# Altium schematic sheet PAB.SchDoc(PAB)
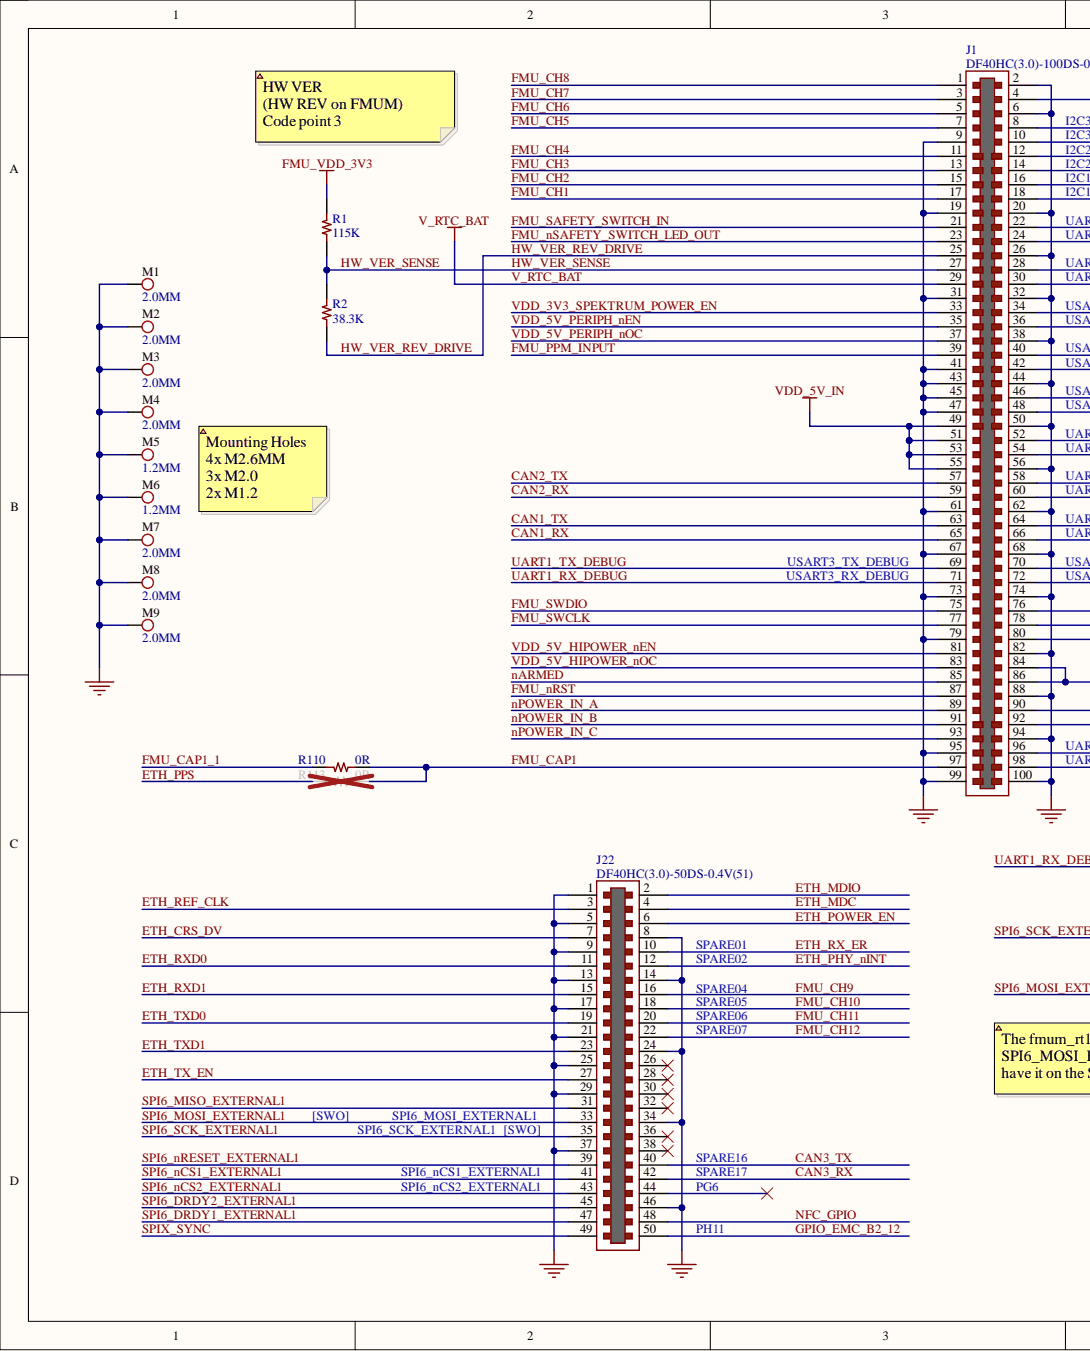
[diagram p0: PAB.SchDoc, left]
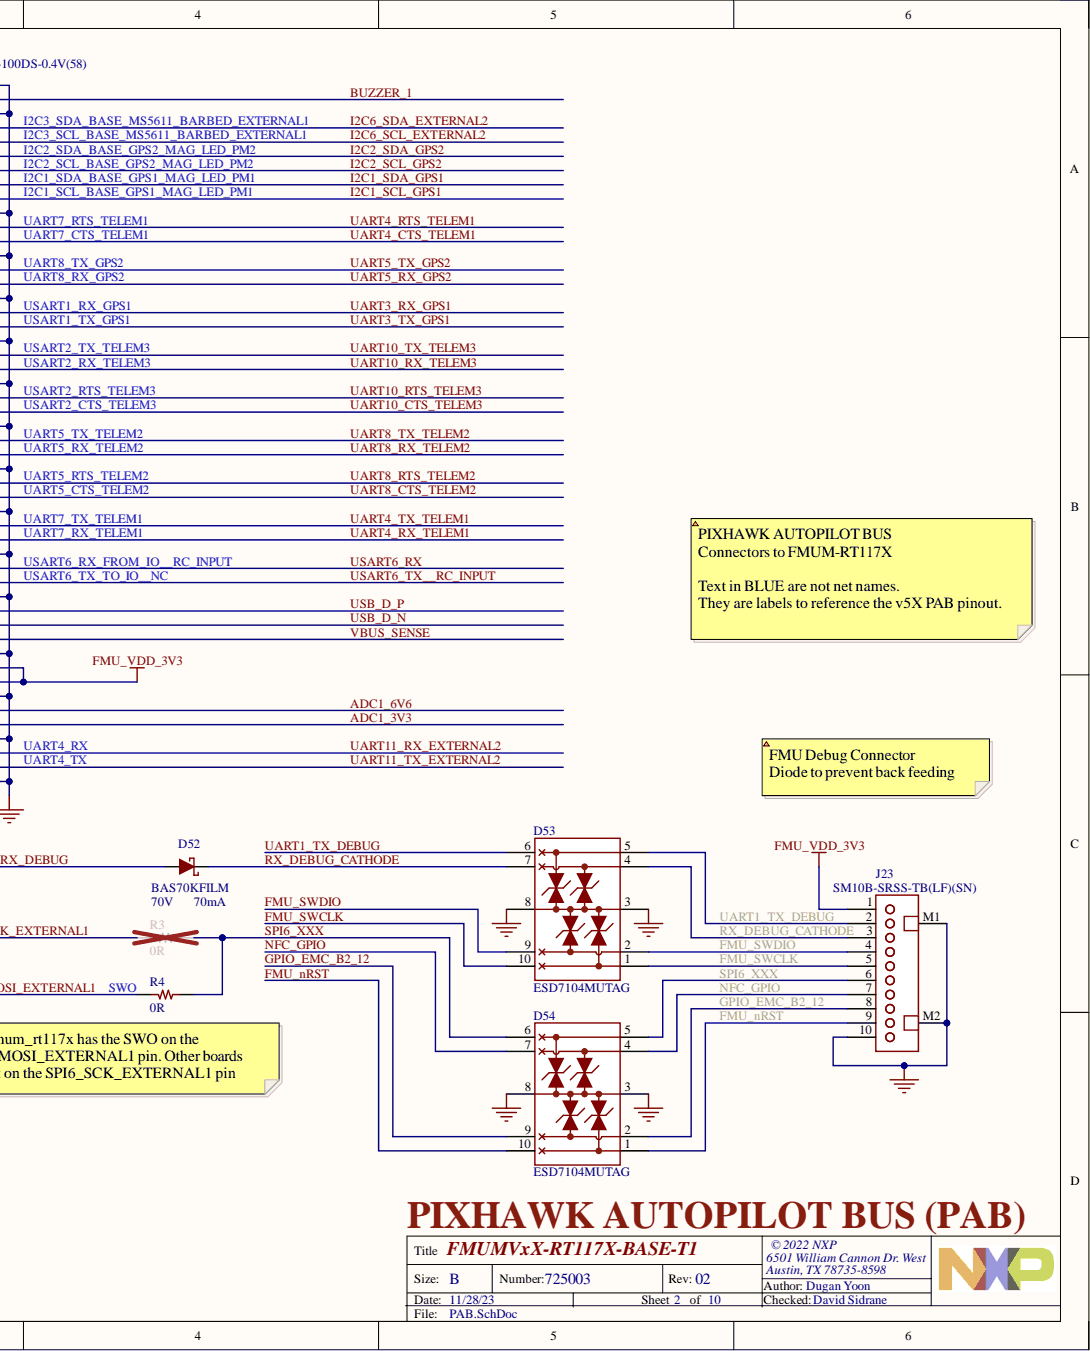
[diagram p1: PAB.SchDoc, right]

## Parts
D52  Current: 70mA  Manufacturer Part Number: BAS70KFILM  [SODFL120X80X70L30X30]  (hidden comment: DIODE SCHOTTKY 70V 70MA SOD523)
D53  Manufacturer Part Number: ESD7104MUTAG  [UDFN10P50_250X100X55L35X20N]  (hidden comment: DIODE TVS BIDIRECTIONAL 5V 10V 10UDFN)
D54  Manufacturer Part Number: ESD7104MUTAG  [UDFN10P50_250X100X55L35X20N]  (hidden comment: DIODE TVS BIDIRECTIONAL 5V 10V 10UDFN)
J1  Manufacturer Part Number: DF40HC(3.0)-100DS-0.4V(58)  [HIROSE_DF40HC3_0-100DS-0_4V51]  (hidden comment: CONN RCPT 100POS 0.4MM SMD GOLD)
J22  Manufacturer Part Number: DF40HC(3.0)-50DS-0.4V(51)  [HIROSE_DF40C-50DS-0-4V51]  (hidden comment: CONN RCPT 50POS 0.4MM SMD GOLD)
J23  Manufacturer Part Number: SM10B-SRSS-TB(LF)(SN)  [JST_SM10B-SRSS-TB]  (hidden comment: CONN HEADER SH 10POS SIDE 1MM SMT)
M1  ID: 2.0MM  [MOUNT_HOLE_2MM_3.6MM_8via_TH]  (hidden comment: MOUNT HOLE 2MM 3.6MM 8VIAS TH)  (no BOM)
M2  ID: 2.0MM  [MOUNT_HOLE_2MM_3.6MM_8via_TH]  (hidden comment: MOUNT HOLE 2MM 3.6MM 8VIAS TH)  (no BOM)
M3  ID: 2.0MM  [MOUNT_HOLE_2MM_3.6MM_8via_TH]  (hidden comment: MOUNT HOLE 2MM 3.6MM 8VIAS TH)  (no BOM)
M4  ID: 2.0MM  [MOUNT_HOLE_2MM_3.6MM_8via_TH]  (hidden comment: MOUNT HOLE 2MM 3.6MM 8VIAS TH)  (no BOM)
M5  ID: 1.2MM  [MOUNT_HOLE_1.2MM_TH]  (hidden comment: MOUNT HOLE 1.2MM TH)  (no BOM)
M6  ID: 1.2MM  [MOUNT_HOLE_1.2MM_TH]  (hidden comment: MOUNT HOLE 1.2MM TH)  (no BOM)
M7  ID: 2.0MM  [MOUNT_HOLE_2MM_3.6MM_8via_TH]  (hidden comment: MOUNT HOLE 2MM 3.6MM 8VIAS TH)  (no BOM)
M8  ID: 2.0MM  [MOUNT_HOLE_2MM_3.6MM_8via_TH]  (hidden comment: MOUNT HOLE 2MM 3.6MM 8VIAS TH)  (no BOM)
M9  ID: 2.0MM  [MOUNT_HOLE_2MM_3.6MM_8via_TH]  (hidden comment: MOUNT HOLE 2MM 3.6MM 8VIAS TH)  (no BOM)
R1  value 115K  [RESC100X50X40L25]  (hidden comment: RES SMD 115K OHM 1% 1/16W 0402)
R2  value 38.3K  [RESC100X50X40L25]  (hidden comment: RES SMD 38.3K OHM 1% 1/16W 0402)
R3  value 0R  [RESC100X50X40L25]  (hidden comment: RES SMD 0 OHM 1% 1/16W 0402)
R4  value 0R  [RESC100X50X40L25]  (hidden comment: RES SMD 0 OHM 1% 1/16W 0402)
R110  value 0R  [RESC100X50X40L25]  (hidden comment: RES SMD 0 OHM 1% 1/16W 0402)
R113  value 0R  [RESC100X50X40L25]  (hidden comment: RES SMD 0 OHM 1% 1/16W 0402)

## Nets
FMU_VDD_3V3: J1.84 J1.86 J23.1 R1.1
GND: D53.3 D53.8 D54.3 D54.8 J1.2 J1.6 J1.9 J1.19 J1.20 J1.26 J1.31 J1.32 J1.38 J1.41 J1.43 J1.44 J1.45 J1.47 J1.50 J1.56 J1.61 J1.62 J1.67 J1.68 J1.73 J1.74 J1.79 J1.82 J1.88 J1.94 J1.95 J1.99 J1.100 J22.1 J22.5 J22.8 J22.9 J22.13 J22.14 J22.17 J22.21 J22.24 J22.25 J22.29 J22.34 J22.37 J22.46 J23.10 J23.M1 J23.M2 M1.1 M2.1 M3.1 M4.1 M5.1 M6.1 M7.1 M8.1 M9.1
VDD_5V_IN: J1.49 J1.51 J1.53 J1.55
ADC1_3V3: J1.92
ADC1_6V6: J1.90
BUZZER_1: J1.4
CAN1_RX: J1.65
CAN1_TX: J1.63
CAN2_RX: J1.59
CAN2_TX: J1.57
CAN3_RX: J22.42
CAN3_TX: J22.40
ETH_CRS_DV: J22.7
ETH_MDC: J22.4
ETH_MDIO: J22.2
ETH_PHY_nINT: J22.12
ETH_POWER_EN: J22.6
ETH_PPS: R113.1
ETH_REF_CLK: J22.3
ETH_RX_ER: J22.10
ETH_RXD0: J22.11
ETH_RXD1: J22.15
ETH_TX_EN: J22.27
ETH_TXD0: J22.19
ETH_TXD1: J22.23
FMU_CAP1: J1.97 R110.2 R113.2
FMU_CAP1_1: R110.1
FMU_CH1: J1.17
FMU_CH2: J1.15
FMU_CH3: J1.13
FMU_CH4: J1.11
FMU_CH5: J1.7
FMU_CH6: J1.5
FMU_CH7: J1.3
FMU_CH8: J1.1
FMU_CH9: J22.16
FMU_CH10: J22.18
FMU_CH11: J22.20
FMU_CH12: J22.22
FMU_nRST: D54.1 D54.10 J1.87 J23.9
FMU_nSAFETY_SWITCH_LED_OUT: J1.23
FMU_PPM_INPUT: J1.39
FMU_SAFETY_SWITCH_IN: J1.21
FMU_SWCLK: D53.1 D53.10 J1.77 J23.5
FMU_SWDIO: D53.2 D53.9 J1.75 J23.4
GPIO_EMC_B2_12: D54.2 D54.9 J22.50 J23.8
HW_VER_REV_DRIVE: J1.25 R2.2
HW_VER_SENSE: J1.27 R1.2 R2.1
I2C1_SCL_GPS1: J1.18
I2C1_SDA_GPS1: J1.16
I2C2_SCL_GPS2: J1.14
I2C2_SDA_GPS2: J1.12
I2C6_SCL_EXTERNAL2: J1.10
I2C6_SDA_EXTERNAL2: J1.8
nARMED: J1.85
NFC_GPIO: D54.4 D54.7 J22.48 J23.7
nPOWER_IN_A: J1.89
nPOWER_IN_B: J1.91
nPOWER_IN_C: J1.93
RX_DEBUG_CATHODE: D52.C D53.4 D53.7 J23.3
SPI6_DRDY1_EXTERNAL1: J22.47
SPI6_DRDY2_EXTERNAL1: J22.45
SPI6_MISO_EXTERNAL1: J22.31
SPI6_MOSI_EXTERNAL1: J22.33 R4.1
SPI6_nCS1_EXTERNAL1: J22.41
SPI6_nCS2_EXTERNAL1: J22.43
SPI6_nRESET_EXTERNAL1: J22.39
SPI6_SCK_EXTERNAL1: J22.35 R3.1
SPI6_XXX: D54.5 D54.6 J23.6 R3.2 R4.2
SPIX_SYNC: J22.49
UART1_RX_DEBUG: D52.A J1.71
UART1_TX_DEBUG: D53.5 D53.6 J1.69 J23.2
UART3_RX_GPS1: J1.34
UART3_TX_GPS1: J1.36
UART4_CTS_TELEM1: J1.24
UART4_RTS_TELEM1: J1.22
UART4_RX_TELEM1: J1.66
UART4_TX_TELEM1: J1.64
UART5_RX_GPS2: J1.30
UART5_TX_GPS2: J1.28
UART8_CTS_TELEM2: J1.60
UART8_RTS_TELEM2: J1.58
UART8_RX_TELEM2: J1.54
UART8_TX_TELEM2: J1.52
UART10_CTS_TELEM3: J1.48
UART10_RTS_TELEM3: J1.46
UART10_RX_TELEM3: J1.42
UART10_TX_TELEM3: J1.40
UART11_RX_EXTERNAL2: J1.96
UART11_TX_EXTERNAL2: J1.98
USART6_RX: J1.70
USART6_TX__RC_INPUT: J1.72
USB_D_N: J1.78
USB_D_P: J1.76
V_RTC_BAT: J1.29
VBUS_SENSE: J1.80
VDD_3V3_SPEKTRUM_POWER_EN: J1.33
VDD_5V_HIPOWER_nEN: J1.81
VDD_5V_HIPOWER_nOC: J1.83
VDD_5V_PERIPH_nEN: J1.35
VDD_5V_PERIPH_nOC: J1.37

Not connected: J22 26 28 30 32 36 38 44

## Library data of the parts
D52 = DIODE_SCHOTTKY_BAS70_SOD523_SMT: Manufacturer: STMicroelectronics; Category: Discrete Semiconductor Products; Component Kind: Standard; ComponentLink1Description: https://www.digikey.com/product-detail/en/stmicroelectronics/BAS70KFILM/497-5695-1-ND/1299948; ComponentLink1URL: https://www.digikey.com/product-detail/en/stmicroelectronics/BAS70KFILM/497-5695-1-ND/1299948; Description: DIODE SCHOTTKY 70V 70MA SOD523; Packaging: Cut Tape (CT); Part Status: Active; Pricing 1: 1=0.28, 10=0.23, 100=0.122, 500=0.08028, 1000=0.05459 (USD); Stock 1: 20067; Supplier 1: Digi-Key; Supplier Part Number 1: 497-5695-1-ND; Voltage: 70V; Library Name: NscDG_Integrated_Library.SchLib
D53 D54 = DIODE_TVS_4X_10UDFN: Manufacturer: ON Semiconductor; Category: Circuit Protection; Component Kind: Standard; ComponentLink1Description: https://www.digikey.com/product-detail/en/on-semiconductor/ESD7104MUTAG/ESD7104MUTAGOSCT-ND/3487538; ComponentLink1URL: https://www.digikey.com/product-detail/en/on-semiconductor/ESD7104MUTAG/ESD7104MUTAGOSCT-ND/3487538; Description: DIODE TVS BIDIRECTIONAL 5V 10V 10UDFN; Operating Temperature: -55°C ~ 125°C (TJ); Packaging: Cut Tape (CT); Part Status: Active; Pricing 1: 1=0.63, 10=0.541, 100=0.40370, 500=0.31716, 1000=0.24508 (USD); Stock 1: 5750; Supplier 1: Digi-Key; Supplier Part Number 1: ESD7104MUTAGOSCT-ND; Library Name: NscDG_Integrated_Library.SchLib
J1 = CONN_RCPT_2X50_RECPT_0.4MM_SMD: Manufacturer: Hirose Electric Co Ltd; Mated Stacking Heights: 3mm; Number of Positions: 100; Pitch: 0.016" (0.40mm); System: Connector; Category: Connectors, Interconnects; Component Kind: Standard; ComponentLink1Description: https://www.digikey.com/products/en/connectors-interconnects/rectangular-connectors-arrays-edge-type-mezzanine-board-to-board/308?k=df40hc%283.0%29-100&k=&pkeyword=df40hc%283.0%29-100&sv=0&pv7=2&sf=0&quantity=&ColumnSort=0&page=1&pageSize=25; ComponentLink1URL: https://www.digikey.com/products/en/connectors-interconnects/rectangular-connectors-arrays-edge-type-mezzanine-board-to-board/308?k=df40hc%283.0%29-100&k=&pkeyword=df40hc%283.0%29-100&sv=0&pv7=2&sf=0&quantity=&ColumnSort=0&page=1&pageSize=25; Description: CONN RCPT 100POS 0.4MM SMD GOLD; Mounting Type: Surface Mount; Number of Rows: 2; Operating Temperature: -65°C ~ 85°C; Packaging: Cut Tape (CT); Part Status: Active; Pricing 1: 1=2.75 (USD); Stock 1: 2220; Supplier 1: Digi-Key; Supplier Part Number 1: H124602CT-ND; Library Name: NscDG_Integrated_Library.SchLib
J22 = CONN_RCPT_2X25_RECPT_0.4MM_SMD: Manufacturer: Hirose Electric Co Ltd; Mated Stacking Heights: 3mm; Number of Positions: 50; Pitch: 0.016" (0.40mm); System: Connector; Category: Connectors, Interconnects; Component Kind: Standard; ComponentLink1Description: https://www.digikey.com/products/en/connectors-interconnects/rectangular-connectors-arrays-edge-type-mezzanine-board-to-board/308?k=DF40HC%283.0%29-50DS-0.4V%2851%29&k=&pkeyword=DF40HC%283.0%29-50DS-0.4V%2851%29&sv=0&pv7=2&sf=0&quantity=&ColumnSort=0&page=1&pageSize=25; ComponentLink1URL: https://www.digikey.com/products/en/connectors-interconnects/rectangular-connectors-arrays-edge-type-mezzanine-board-to-board/308?k=DF40HC%283.0%29-50DS-0.4V%2851%29&k=&pkeyword=DF40HC%283.0%29-50DS-0.4V%2851%29&sv=0&pv7=2&sf=0&quantity=&ColumnSort=0&page=1&pageSize=25; Description: CONN RCPT 50POS 0.4MM SMD GOLD; Mounting Type: Surface Mount; Number of Rows: 2; Operating Temperature: -65°C ~ 85°C; Packaging: Cut Tape (CT); Part Status: Active; Pricing 1: 1=1.05, 10=0.926, 25=0.8712, 50=0.835, 100=0.7986, 250=0.726, 500=0.6534, 1000=0.5808 (USD); Stock 1: 8712; Supplier 1: Digi-Key; Supplier Part Number 1: H11915CT-ND; Library Name: NscDG_Integrated_Library.SchLib
J23 = CONN_JST_SH_10PIN_RA_SMT: Manufacturer: JST Sales America Inc.; System: Connector; Voltage - Rated: 50V; Category: Connectors, Interconnects; Component Kind: Standard; ComponentLink1Description: https://www.digikey.com/products/en/connectors-interconnects/rectangular-connectors-headers-male-pins/314?k=SM10B-SRSS&k=&pkeyword=SM10B-SRSS&pv1989=0&sf=0&FV=ffe0013a%2C1c0002&quantity=&ColumnSort=0&page=1&pageSize=25; ComponentLink1URL: https://www.digikey.com/products/en/connectors-interconnects/rectangular-connectors-headers-male-pins/314?k=SM10B-SRSS&k=&pkeyword=SM10B-SRSS&pv1989=0&sf=0&FV=ffe0013a%2C1c0002&quantity=&ColumnSort=0&page=1&pageSize=25; Description: CONN HEADER SH 10POS SIDE 1MM SMT; Operating Temperature: -25°C ~ 85°C; Packaging: Cut Tape (CT); Part Status: Active; Pricing 1: 1=1.06, 10=9.32, 100=0.8037, 500=0.65758, 1000=0.58452 (USD); Stock 1: 62595; Supplier 1: Digi-Key; Supplier Part Number 1: 455-1810-1-ND; Library Name: NscDG_Integrated_Library.SchLib
M1-M4 M7-M9 = MOUNT_HOLE_2MM_3.6MM_8VIAS_TH: CATEGORY: Mechanical; Description: MOUNT HOLE 2MM 3.6MM 8VIAS TH; Library Name: NscDG_Integrated_Library.SchLib
M5 M6 = MOUNT_HOLE_1.2MM_TH: CATEGORY: Mechanical; Description: MOUNT HOLE 1.2MM TH; Library Name: NscDG_Integrated_Library.SchLib
R1 = RES_115K_1%_1/16W_0402: Manufacturer: Vishay Dale; Manufacturer Part Number: CRCW0402115KFKED; Category: Resistors; Component Kind: Standard; ComponentLink1Description: https://www.digikey.com/products/en/resistors/chip-resistor-surface-mount/52?k=&pkeyword=&sv=0&v=541&pv2085=u115+kOhms&sf=0&FV=2%7C7854%2C3%7C1131%2C7%7C2%2C1989%7C0%2C-8%7C52%2C174%7C411742&quantity=&ColumnSort=0&page=1&pageSize=25; ComponentLink1URL: https://www.digikey.com/products/en/resistors/chip-resistor-surface-mount/52?k=&pkeyword=&sv=0&v=541&pv2085=u115+kOhms&sf=0&FV=2%7C7854%2C3%7C1131%2C7%7C2%2C1989%7C0%2C-8%7C52%2C174%7C411742&quantity=&ColumnSort=0&page=1&pageSize=25; Description: RES SMD 115K OHM 1% 1/16W 0402; Operating Temperature: -55°C ~ 155°C; Packaging: Cut Tape (CT); Part Status: Active; Power: 1/16W; Pricing 1: 1=0.1, 10=0.014, 100=0.0056, 1000=0.00253, 2500=0.0022, 5000=0.00181 (USD); Stock 1: 32374; Supplier 1: Digi-Key; Supplier Part Number 1: 541-115KLCT-ND; Tolerance: ±1%; Library Name: NscDG_Integrated_Library.SchLib
R2 = RES_38.3K_1%_1/16W_0402: Manufacturer: Yageo; Manufacturer Part Number: RC0402FR-0738K3L; Category: Resistors; Component Kind: Standard; ComponentLink1Description: https://www.digikey.com/products/en/resistors/chip-resistor-surface-mount/52?k=RC0402FR-0738K3L&k=&pkeyword=RC0402FR-0738K3L&sv=0&pv7=2&sf=0&quantity=&ColumnSort=0&page=1&pageSize=25; ComponentLink1URL: https://www.digikey.com/products/en/resistors/chip-resistor-surface-mount/52?k=RC0402FR-0738K3L&k=&pkeyword=RC0402FR-0738K3L&sv=0&pv7=2&sf=0&quantity=&ColumnSort=0&page=1&pageSize=25; Description: RES SMD 38.3K OHM 1% 1/16W 0402; Operating Temperature: -55°C ~ 155°C; Packaging: Cut Tape (CT); Part Status: Active; Power: 1/16W; Pricing 1: 1=0.1, 10=0.014, 100=0.0056, 1000=0.00253, 2500=0.0022, 5000=0.00181 (USD); Stock 1: 92389; Supplier 1: Digi-Key; Supplier Part Number 1: YAG3141CT-ND; Tolerance: ±1%; Library Name: NscDG_Integrated_Library.SchLib
R3 R4 R110 R113 = RES_0R_1%_1/16W_0402: Manufacturer: Yageo; Manufacturer Part Number: RC0402JR-070RL; Category: Resistors; Component Kind: Standard; ComponentLink1Description: https://www.digikey.com/product-detail/en/yageo/RC0402JR-070RL/311-0.0JRCT-ND/729353; ComponentLink1URL: https://www.digikey.com/product-detail/en/yageo/RC0402JR-070RL/311-0.0JRCT-ND/729353; Description: RES SMD 0 OHM 1% 1/16W 0402; Operating Temperature: -55°C ~ 155°C; Packaging: Cut Tape (CT); Part Status: Active; Power: 1/16W; Pricing 1: 1=0.1, 10=0.014, 100=0.0056, 1000=0.00253, 2500=0.0022, 5000=0.00181 (USD); Stock 1: 34962204; Supplier 1: Digi-Key; Supplier Part Number 1: 311-0.0JRCT-ND; Tolerance: ±1%; Library Name: NscDG_Integrated_Library.SchLib
